# Altium schematic sheet gpio.SchDoc(gpio)
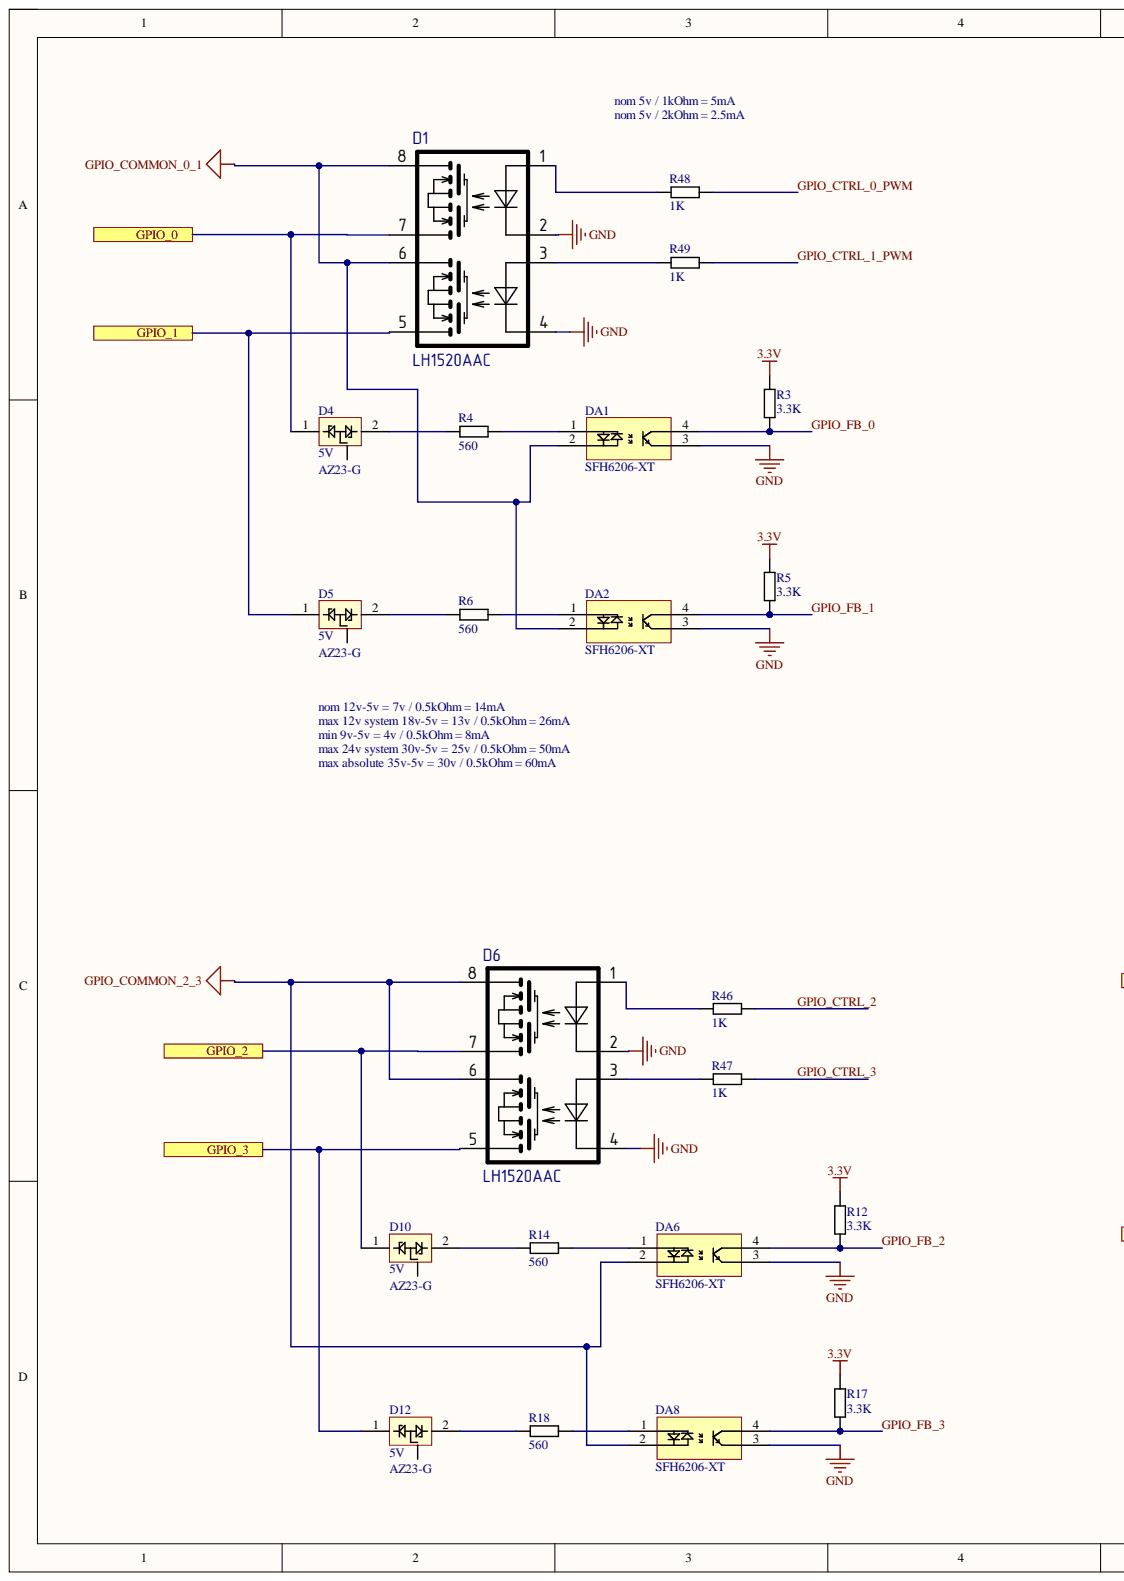
[diagram p0: gpio.SchDoc, left]
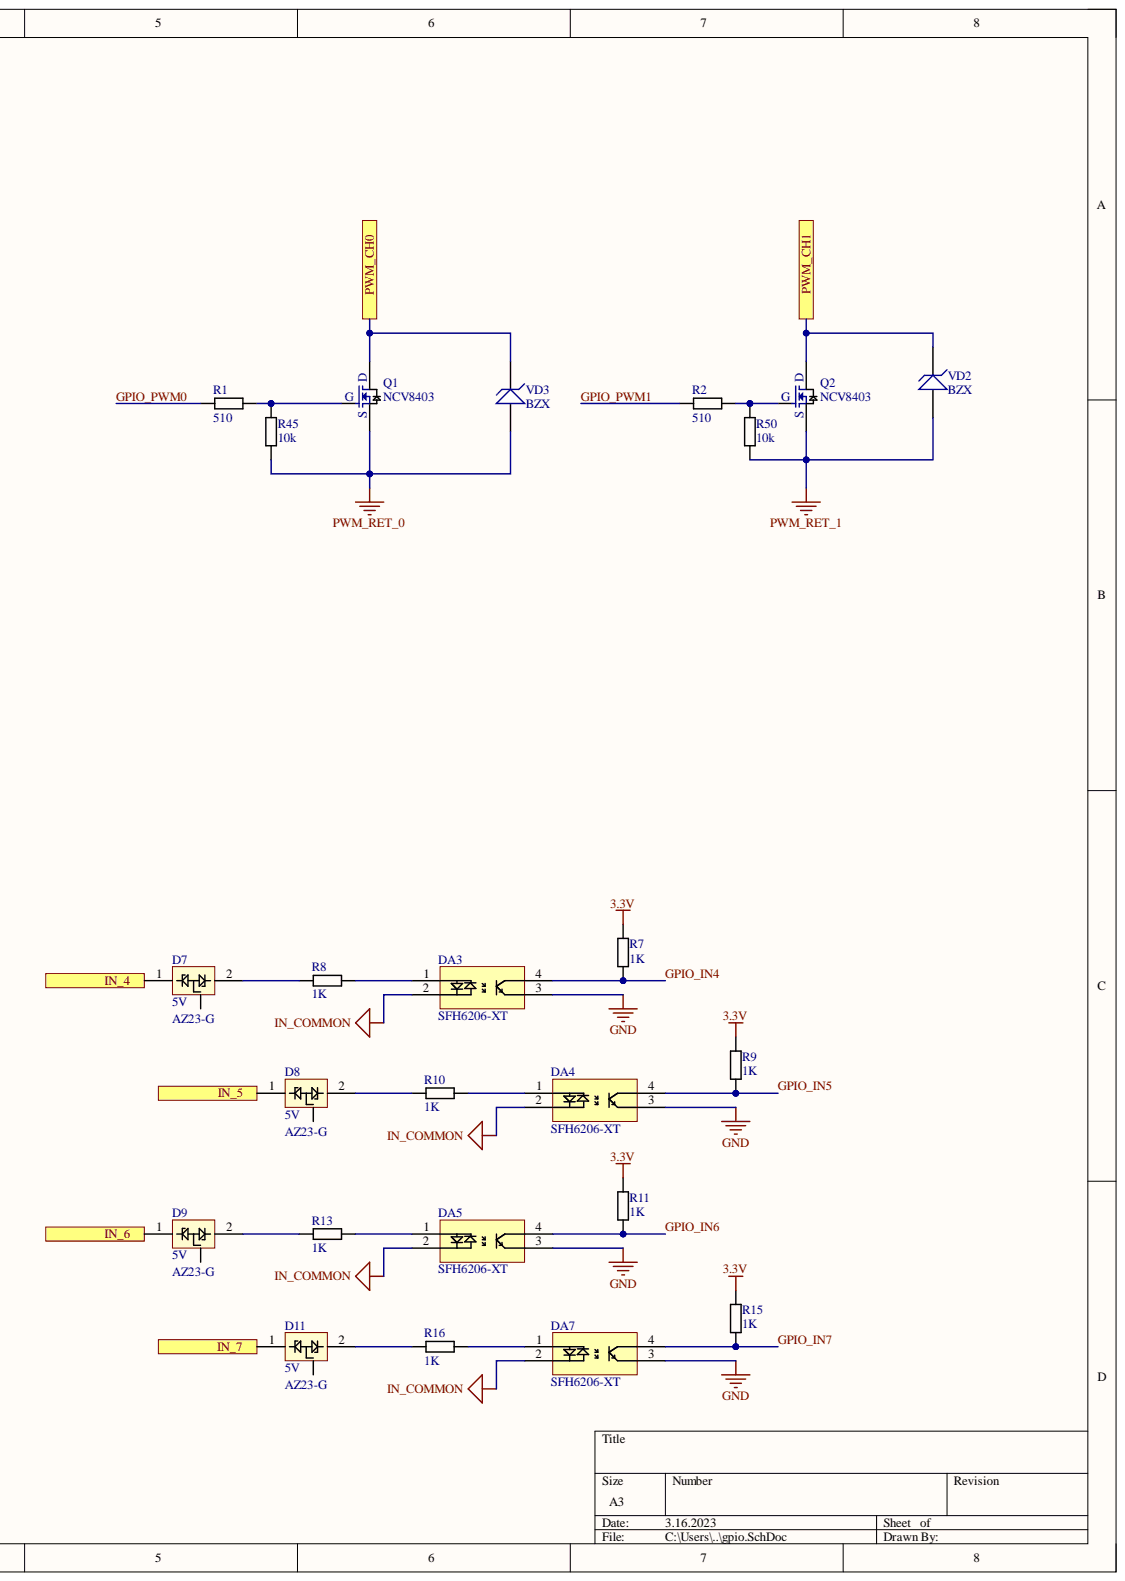
[diagram p1: gpio.SchDoc, right]

## Parts
D1  LH1520AAC  [SMD-8-254P1500X1500]
D4  AZ23-G  value 5V  [SOT23-3L]
D5  AZ23-G  value 5V  [SOT23-3L]
D6  LH1520AAC  [SMD-8-254P1500X1500]
D7  AZ23-G  value 5V  [SOT23-3L]
D8  AZ23-G  value 5V  [SOT23-3L]
D9  AZ23-G  value 5V  [SOT23-3L]
D10  AZ23-G  value 5V  [SOT23-3L]
D11  AZ23-G  value 5V  [SOT23-3L]
D12  AZ23-G  value 5V  [SOT23-3L]
DA1  SFH6206-XT  [SO4_w_3D_Body]
DA2  SFH6206-XT  [SO4_w_3D_Body]
DA3  SFH6206-XT  [SO4_w_3D_Body]
DA4  SFH6206-XT  [SO4_w_3D_Body]
DA5  SFH6206-XT  [SO4_w_3D_Body]
DA6  SFH6206-XT  [SO4_w_3D_Body]
DA7  SFH6206-XT  [SO4_w_3D_Body]
DA8  SFH6206-XT  [SO4_w_3D_Body]
Q1  NCV8403  [DPAK-3_TO230P990-3_4M]
Q2  NCV8403  [DPAK-3_TO230P990-3_4M]
R1  value 510  [RESC2012L]  (hidden comment: Res3)
R2  value 510  [RESC2012L]  (hidden comment: Res3)
R3  value 3.3K  [RESC2012L]  (hidden comment: Res3)
R4  value 560  [RESC2012L]  (hidden comment: Res3)
R5  value 3.3K  [RESC2012L]  (hidden comment: Res3)
R6  value 560  [RESC2012L]  (hidden comment: Res3)
R7  value 1K  [RESC2012L]  (hidden comment: Res3)
R8  value 1K  [RESC2012L]  (hidden comment: Res3)
R9  value 1K  [RESC2012L]  (hidden comment: Res3)
R10  value 1K  [RESC2012L]  (hidden comment: Res3)
R11  value 1K  [RESC2012L]  (hidden comment: Res3)
R12  value 3.3K  [RESC2012L]  (hidden comment: Res3)
R13  value 1K  [RESC2012L]  (hidden comment: Res3)
R14  value 560  [RESC2012L]  (hidden comment: Res3)
R15  value 1K  [RESC2012L]  (hidden comment: Res3)
R16  value 1K  [RESC2012L]  (hidden comment: Res3)
R17  value 3.3K  [RESC2012L]  (hidden comment: Res3)
R18  value 560  [RESC2012L]  (hidden comment: Res3)
R45  value 10k  [RESC2012L]  (hidden comment: Res3)
R46  value 1K  [RESC2012L]  (hidden comment: Res3)
R47  value 1K  [RESC2012L]  (hidden comment: Res3)
R48  value 1K  [RESC2012L]  (hidden comment: Res3)
R49  value 1K  [RESC2012L]  (hidden comment: Res3)
R50  value 10k  [RESC2012L]  (hidden comment: Res3)
VD2  BZX  [DO-214AC]
VD3  BZX  [DO-214AC]

## Ports
GPIO_0, GPIO_1, GPIO_2, GPIO_3, IN_4, IN_5, IN_6, IN_7, PWM_CH0, PWM_CH1

## Nets
3.3V: R3.1 R5.1 R7.1 R9.1 R11.1 R12.1 R15.1 R17.1
GND: D1.2 D1.4 D6.2 D6.4 DA1.3 DA2.3 DA3.3 DA4.3 DA5.3 DA6.3 DA7.3 DA8.3
GPIO_COMMON_0_1: D1.6 D1.8 DA1.2 DA2.2
GPIO_COMMON_2_3: D6.6 D6.8 DA6.2 DA8.2
IN_COMMON: DA3.2 DA4.2 DA5.2 DA7.2
PWM_RET_0: Q1.2 R45.1 VD3.2
PWM_RET_1: Q2.2 R50.1 VD2.2
GPIO_0: D1.7 D4.1 ; port GPIO_0
GPIO_1: D1.5 D5.1 ; port GPIO_1
GPIO_2: D6.7 D10.1 ; port GPIO_2
GPIO_3: D6.5 D12.1 ; port GPIO_3
GPIO_CTRL_0_PWM: R48.1
GPIO_CTRL_1_PWM: R49.1
GPIO_CTRL_2: R46.1
GPIO_CTRL_3: R47.1
GPIO_FB_0: DA1.4 R3.2
GPIO_FB_1: DA2.4 R5.2
GPIO_FB_2: DA6.4 R12.2
GPIO_FB_3: DA8.4 R17.2
GPIO_IN4: DA3.4 R7.2
GPIO_IN5: DA4.4 R9.2
GPIO_IN6: DA5.4 R11.2
GPIO_IN7: DA7.4 R15.2
GPIO_PWM0: R1.1
GPIO_PWM1: R2.1
IN_4: D7.1 ; port IN_4
IN_5: D8.1 ; port IN_5
IN_6: D9.1 ; port IN_6
IN_7: D11.1 ; port IN_7
PWM_CH0: Q1.3 VD3.1 ; port PWM_CH0
PWM_CH1: Q2.3 VD2.1 ; port PWM_CH1
NetD1_1: D1.1 R48.2
NetD1_3: D1.3 R49.2
NetD4_2: D4.2 R4.2
NetD5_2: D5.2 R6.2
NetD6_1: D6.1 R46.2
NetD6_3: D6.3 R47.2
NetD7_2: D7.2 R8.2
NetD8_2: D8.2 R10.2
NetD9_2: D9.2 R13.2
NetD10_2: D10.2 R14.2
NetD11_2: D11.2 R16.2
NetD12_2: D12.2 R18.2
NetDA1_1: DA1.1 R4.1
NetDA2_1: DA2.1 R6.1
NetDA3_1: DA3.1 R8.1
NetDA4_1: DA4.1 R10.1
NetDA5_1: DA5.1 R13.1
NetDA6_1: DA6.1 R14.1
NetDA7_1: DA7.1 R16.1
NetDA8_1: DA8.1 R18.1
NetQ1_1: Q1.1 R1.2 R45.2
NetQ2_1: Q2.1 R2.2 R50.2

Not connected: D4 3; D5 3; D7 3; D8 3; D9 3; D10 3; D11 3; D12 3

## Library data of the parts
D1 D6 = U: LH1520: Component Kind: Standard; Library Name: A1_.SCHLIB; Type: LH1520AAC
D4 D5 D7-D12 = AZ23-G: Small Signal Zener Diodes, Dual; Component Kind: Standard; Library Name: SA_AltiumSchLib.SchLib
DA1-DA8 = SFH6206-X: AC Input Photo Coupler; Component Kind: Standard; Library Name: SA_AltiumSchLib.SchLib
Q1 Q2 = MOSFET-N_2n7002: N-Channel MOSFET  w diode; Component Kind: Standard; Library Name: SA_AltiumSchLib.SchLib; Simulation: NMOS
R1-R18 R45-R50 = Res3: Resistor; Component Kind: Standard; LatestRevisionDate: 29-May-2009; LatestRevisionNote: IPC-7351 Footprint Added.; Library Name: SA_AltiumSchLib.SchLib; PackageDescription: Chip Resistor; PackageReference: J1-0603; Published: 8-Jun-2000; Signal Integrity: Res; Simulation: RESISTOR; Publisher: Altium Limited
VD2 VD3 = Voltage regulator diodes (Zener): Zener Diode; Component Kind: Standard; Library Name: SA_AltiumSchLib.SchLib; Signal Integrity: D Zener; Simulation: ZENER
